# Altium schematic sheet A1-MAIN.SchDoc
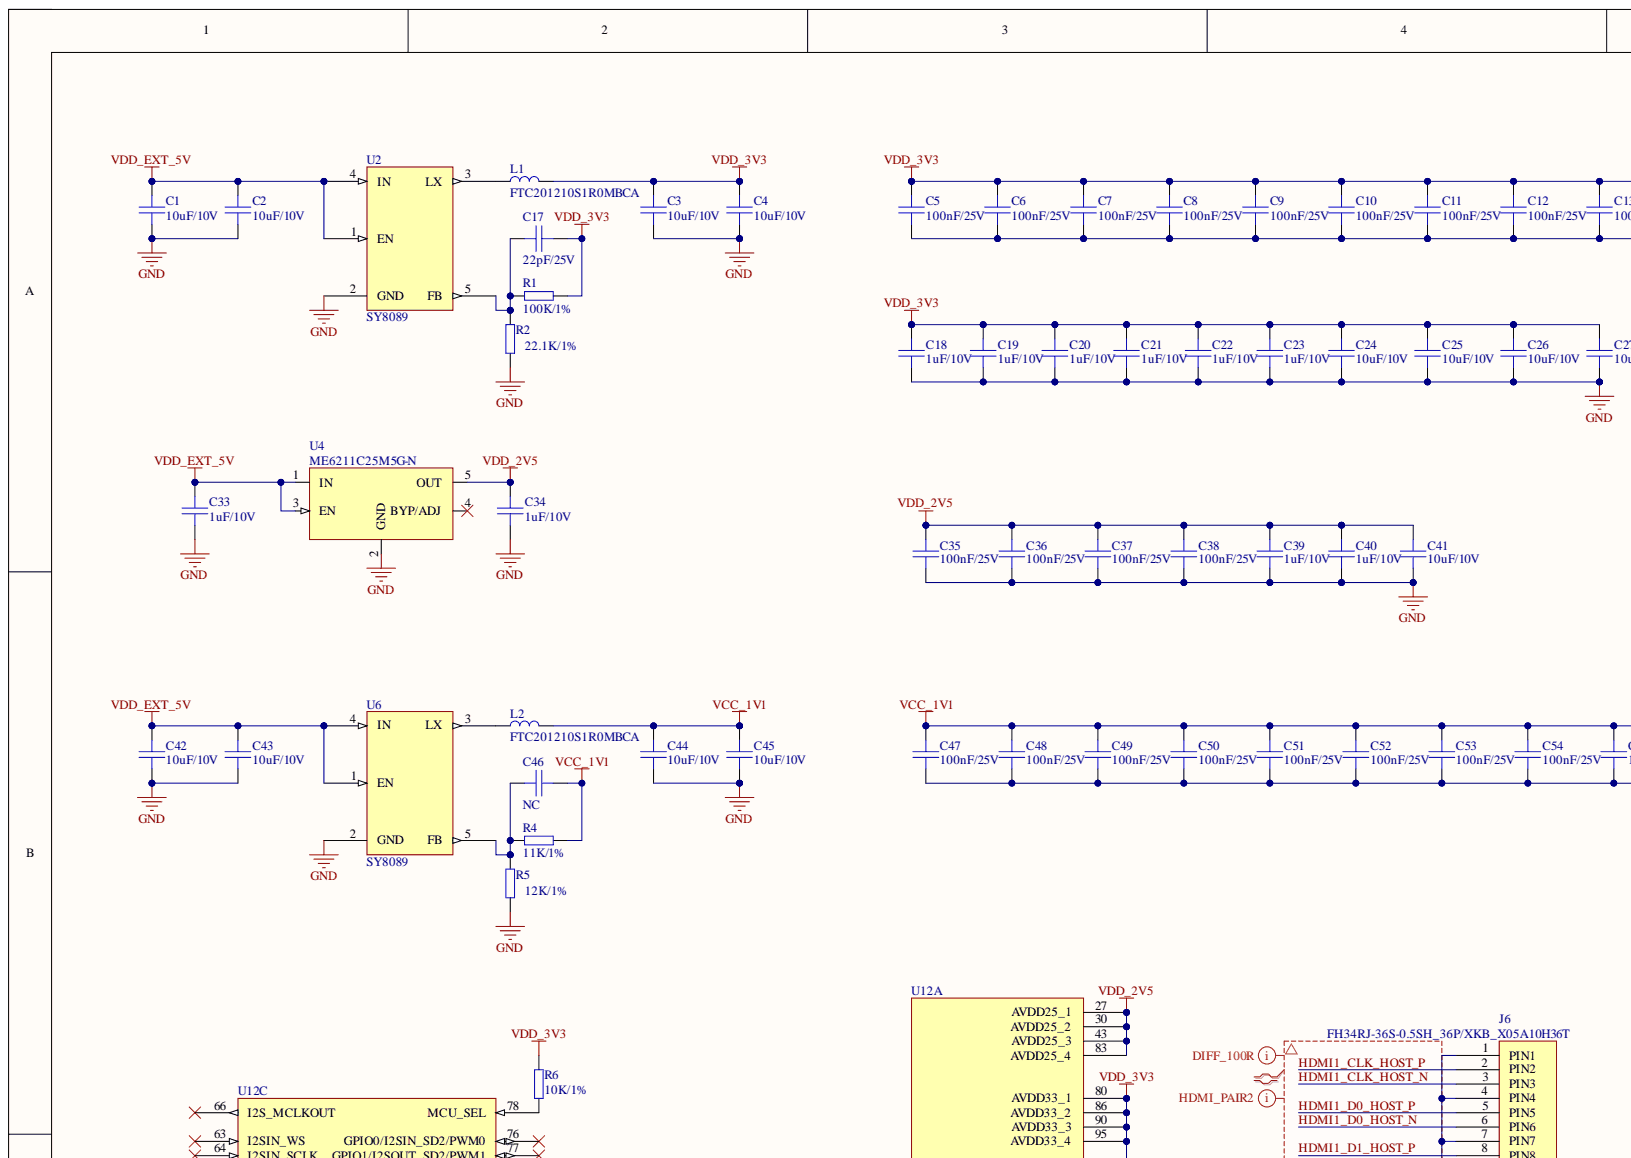
[diagram p0: A1-MAIN.SchDoc, top left]
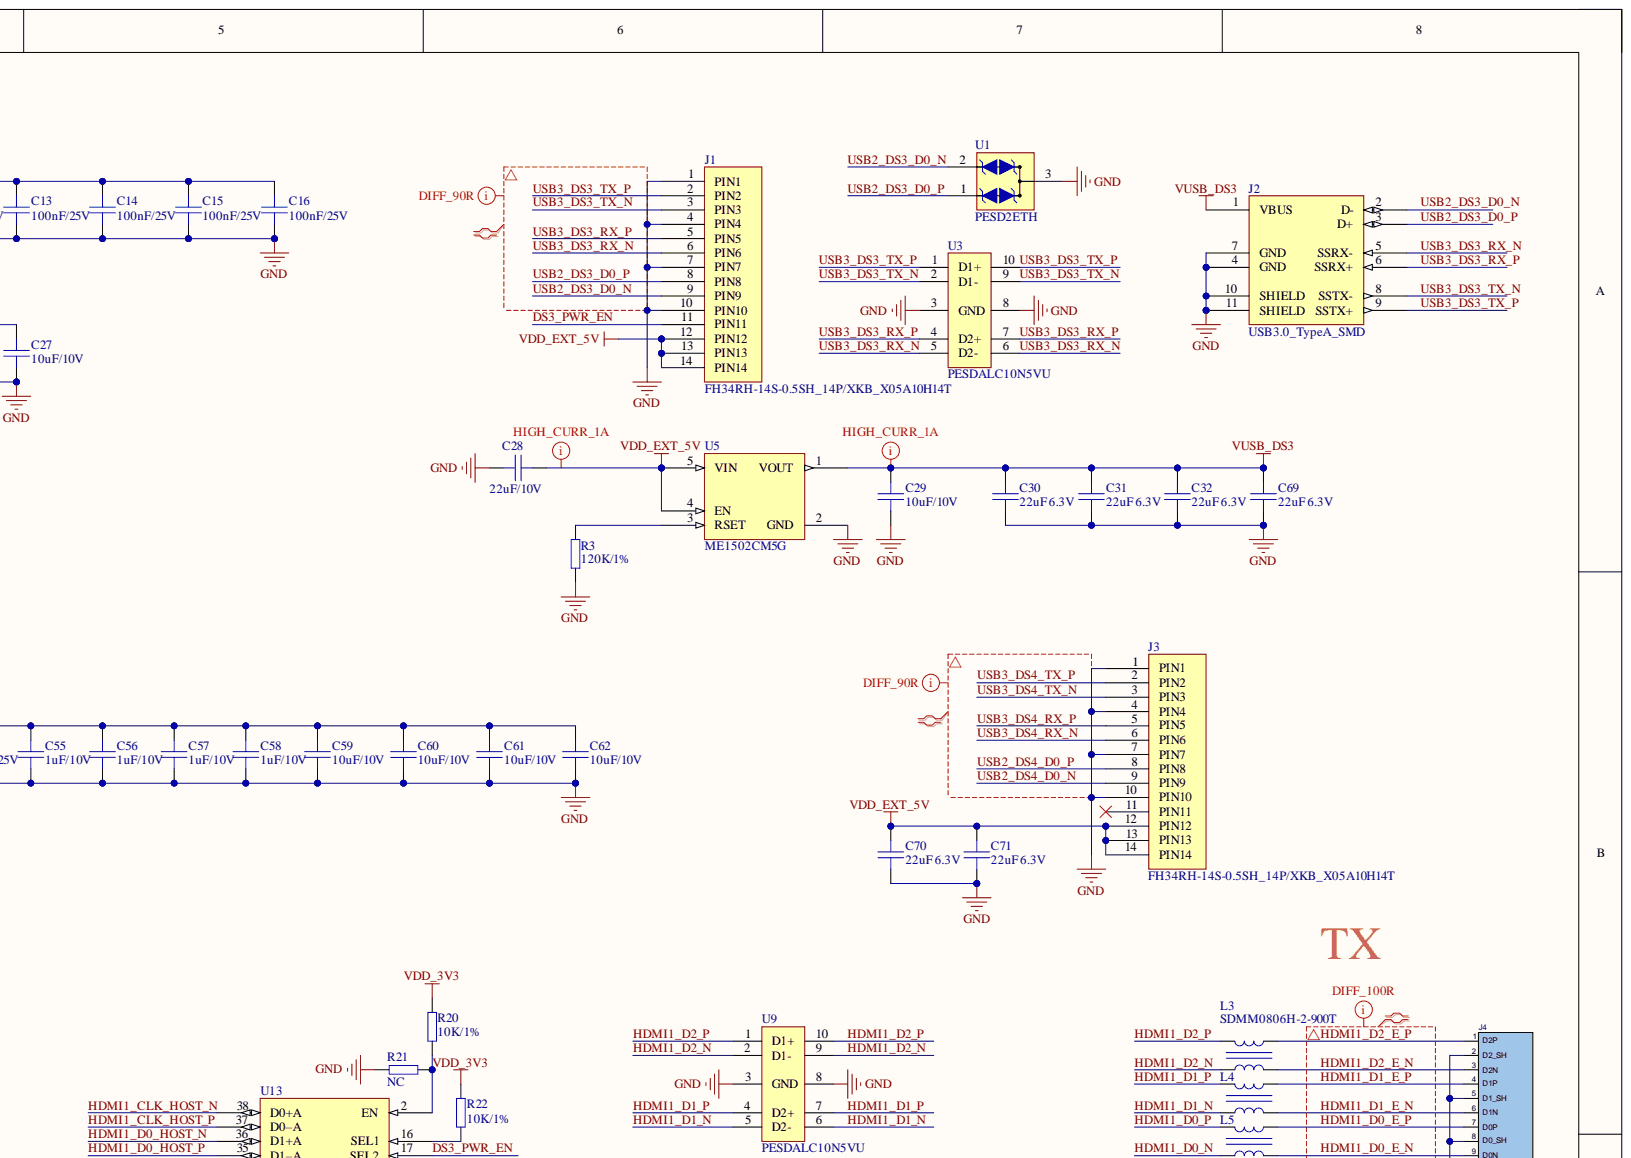
[diagram p1: A1-MAIN.SchDoc, top right]
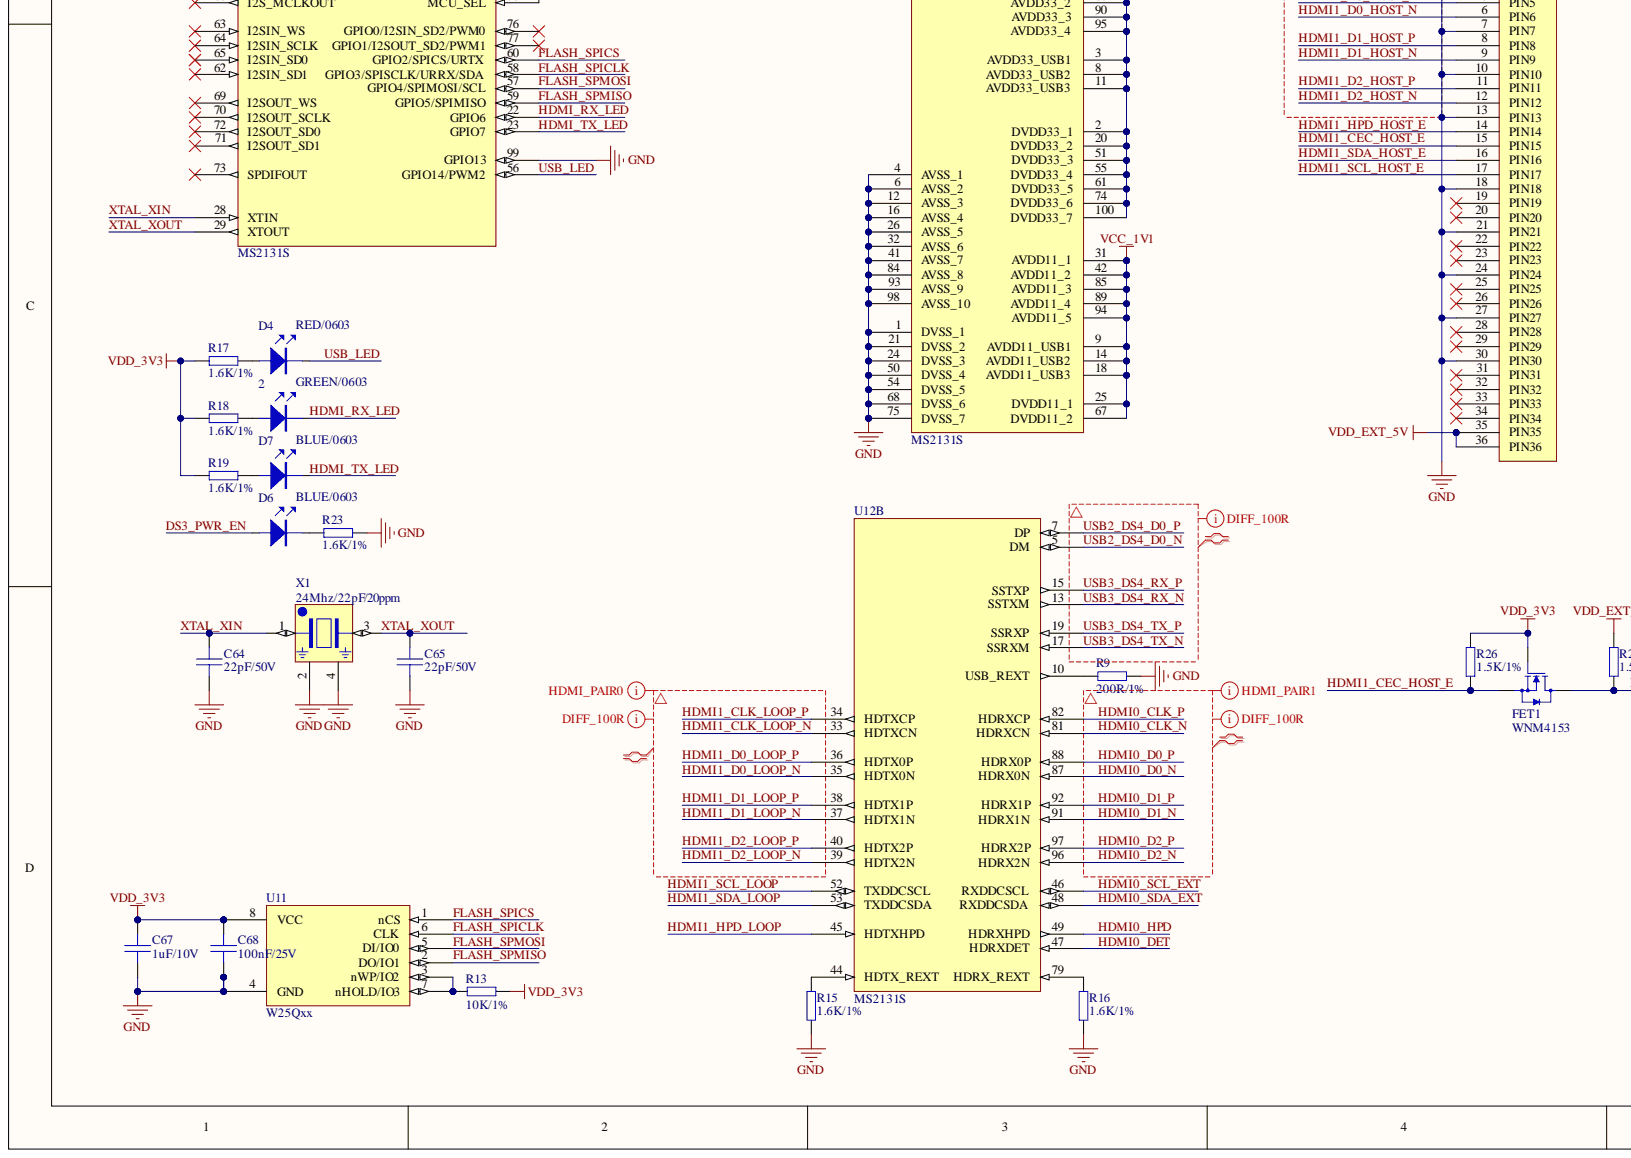
[diagram p2: A1-MAIN.SchDoc, bottom left]
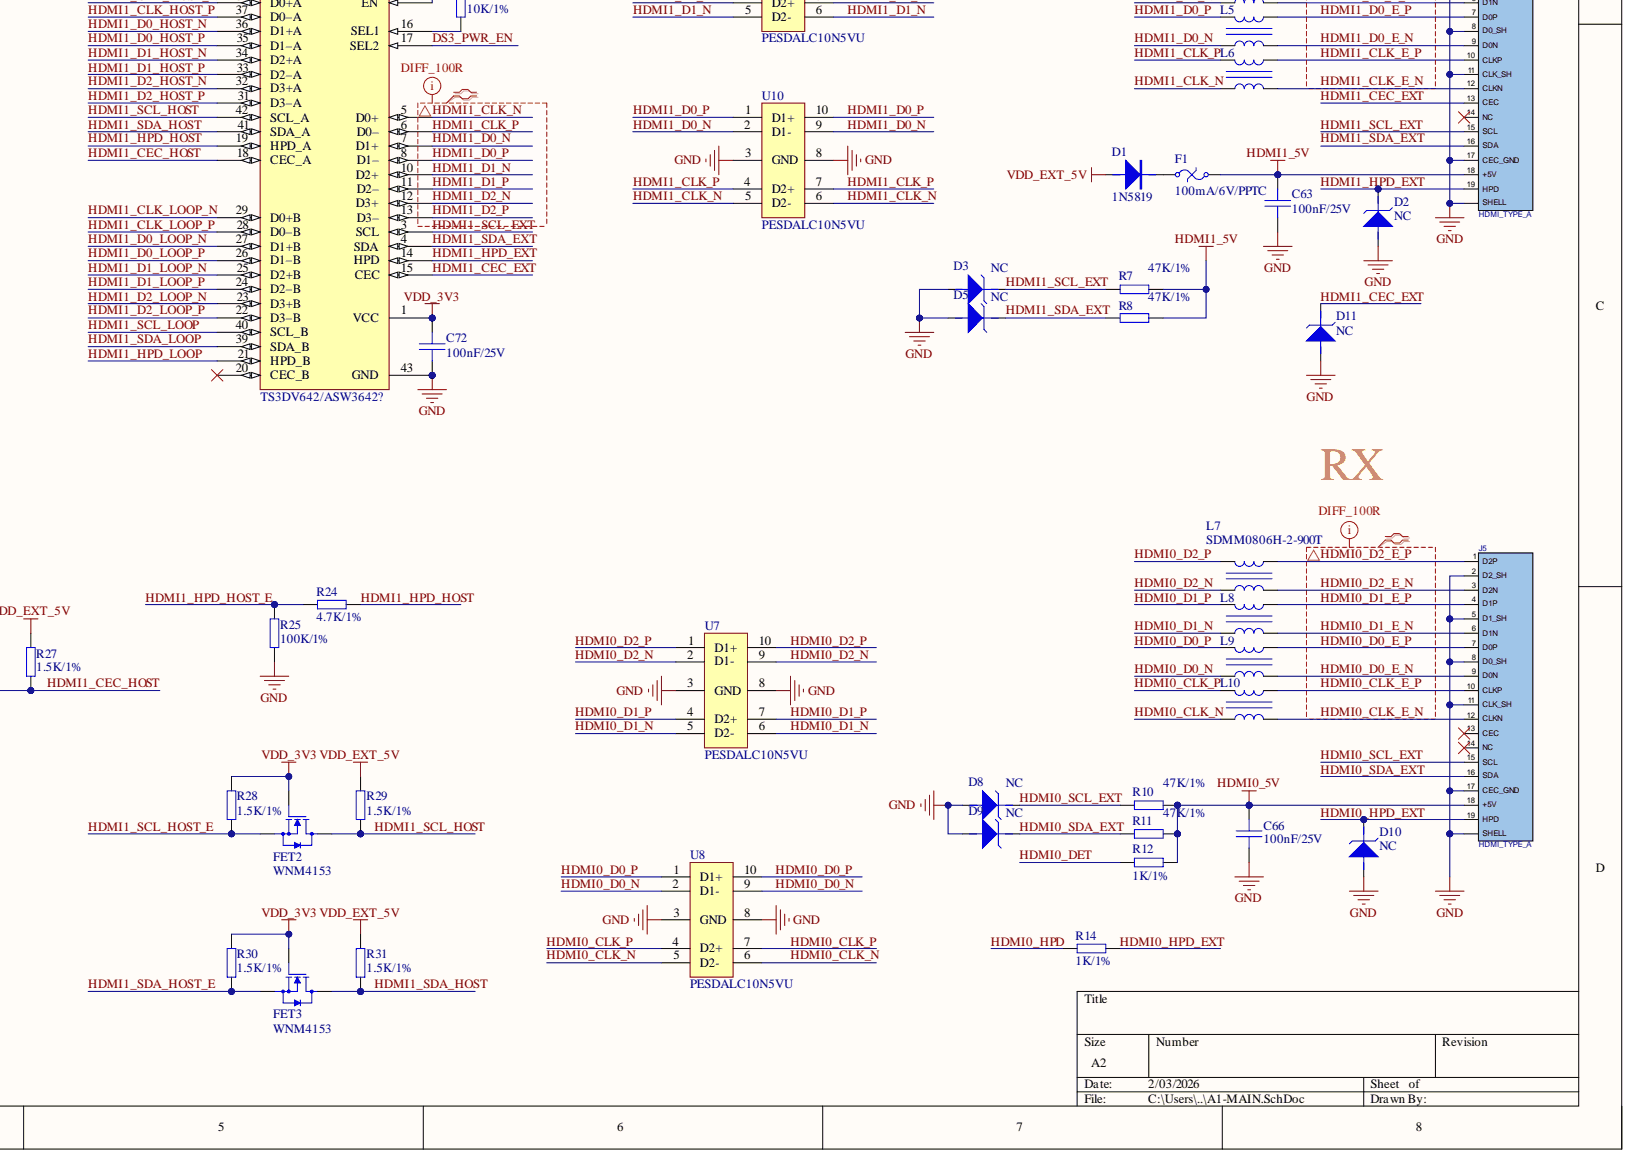
[diagram p3: A1-MAIN.SchDoc, bottom right]

## Parts
2  GREEN/0603  [SMT_LED_0603]
C1  10uF/10V  [C0402-L-HD]
C2  10uF/10V  [C0402-L-HD]
C3  10uF/10V  [C0402-L-HD]
C4  10uF/10V  [C0402-L-HD]
C5  100nF/25V  [C0402-HD]
C6  100nF/25V  [C0402-HD]
C7  100nF/25V  [C0402-HD]
C8  100nF/25V  [C0402-HD]
C9  100nF/25V  [C0402-HD]
C10  100nF/25V  [C0402-HD]
C11  100nF/25V  [C0402-HD]
C12  100nF/25V  [C0402-HD]
C13  100nF/25V  [C0402-HD]
C14  100nF/25V  [C0402-HD]
C15  100nF/25V  [C0402-HD]
C16  100nF/25V  [C0402-HD]
C17  22pF/25V  [C0402-HD]
C18  1uF/10V  [C0402-HD]
C19  1uF/10V  [C0402-HD]
C20  1uF/10V  [C0402-HD]
C21  1uF/10V  [C0402-HD]
C22  1uF/10V  [C0402-HD]
C23  1uF/10V  [C0402-HD]
C24  10uF/10V  [C0402-L-HD]
C25  10uF/10V  [C0402-L-HD]
C26  10uF/10V  [C0402-L-HD]
C27  10uF/10V  [C0402-L-HD]
C28  22uF/10V  [C0603-L-HD]
C29  10uF/10V  [C0402-L-HD]
C30  22uF 6.3V  [C0603-L-HD]
C31  22uF 6.3V  [C0603-L-HD]
C32  22uF 6.3V  [C0603-L-HD]
C33  1uF/10V  [C0402-HD]
C34  1uF/10V  [C0402-HD]
C35  100nF/25V  [C0402-HD]
C36  100nF/25V  [C0402-HD]
C37  100nF/25V  [C0402-HD]
C38  100nF/25V  [C0402-HD]
C39  1uF/10V  [C0402-HD]
C40  1uF/10V  [C0402-HD]
C41  10uF/10V  [C0402-L-HD]
C42  10uF/10V  [C0402-L-HD]
C43  10uF/10V  [C0402-L-HD]
C44  10uF/10V  [C0402-L-HD]
C45  10uF/10V  [C0402-L-HD]
C46  NC  [C0402-HD]
C47  100nF/25V  [C0402-HD]
C48  100nF/25V  [C0402-HD]
C49  100nF/25V  [C0402-HD]
C50  100nF/25V  [C0402-HD]
C51  100nF/25V  [C0402-HD]
C52  100nF/25V  [C0402-HD]
C53  100nF/25V  [C0402-HD]
C54  100nF/25V  [C0402-HD]
C55  1uF/10V  [C0402-HD]
C56  1uF/10V  [C0402-HD]
C57  1uF/10V  [C0402-HD]
C58  1uF/10V  [C0402-HD]
C59  10uF/10V  [C0402-L-HD]
C60  10uF/10V  [C0402-L-HD]
C61  10uF/10V  [C0402-L-HD]
C62  10uF/10V  [C0402-L-HD]
C63  100nF/25V  [C0402-HD]
C64  22pF/50V  [C0402-HD]
C65  22pF/50V  [C0402-HD]
C66  100nF/25V  [C0402-HD]
C67  1uF/10V  [C0402-HD]
C68  100nF/25V  [C0402-HD]
C69  22uF 6.3V  [C0603-L-HD]
C70  22uF 6.3V  [C0603-L-HD]
C71  22uF 6.3V  [C0603-L-HD]
C72  100nF/25V  [C0402-HD]
D1  1N5819  [SMT_DIODE_SOD_323]
D2  NC  [SOD-323FL-HD]
D3  NC  [SOD-323FL-HD]
D4  RED/0603  [SMT_LED_0603]
D5  NC  [SOD-323FL-HD]
D6  BLUE/0603  [SMT_LED_0603]
D7  BLUE/0603  [SMT_LED_0603]
D8  NC  [SOD-323FL-HD]
D9  NC  [SOD-323FL-HD]
D10  NC  [SOD-323FL-HD]
D11  NC  [SOD-323FL-HD]
F1  100mA/6V/PPTC  [SMT_FUSE_0805]
FET1  WNM4153  [SOT-233-HD]
FET2  WNM4153  [SOT-233-HD]
FET3  WNM4153  [SOT-233-HD]
J1  FH34RH-14S-0.5SH_14P/XKB_X05A10H14T  [FH34RH-14S-0.5SH_14P]
J2  USB3.0_TypeA_SMD  [USB-3.0-SMD_U-A-39DS-Y-1]
J3  FH34RH-14S-0.5SH_14P/XKB_X05A10H14T  [FH34RH-14S-0.5SH_14P]
J4  HDMI_TYPE_A  [CON_HDMI_A_SMD]
J5  HDMI_TYPE_A  [CON_HDMI_A_SMD]
J6  FH34RJ-36S-0.5SH_36P/XKB_X05A10H36T  [FH34RJ-36S-0.5SH_36P]
L1  FTC201210S1R0MBCA  [FTC201210S]
L2  FTC201210S1R0MBCA  [FTC201210S]
L3  SDMM0806H-2-900T  [SDMM0806]
L4  SDMM0806H-2-900T  [SDMM0806]
L5  SDMM0806H-2-900T  [SDMM0806]
L6  SDMM0806H-2-900T  [SDMM0806]
L7  SDMM0806H-2-900T  [SDMM0806]
L8  SDMM0806H-2-900T  [SDMM0806]
L9  SDMM0806H-2-900T  [SDMM0806]
L10  SDMM0806H-2-900T  [SDMM0806]
R1  100K/1%  [R0402-HD]
R2  22.1K/1%  [R0402-HD]
R3  120K/1%  [R0402-HD]
R4  11K/1%  [R0402-HD]
R5  12K/1%  [R0402-HD]
R6  10K/1%  [R0402-HD]
R7  47K/1%  [R0402-HD]
R8  47K/1%  [R0402-HD]
R9  200R/1%  [R0402-HD]
R10  47K/1%  [R0402-HD]
R11  47K/1%  [R0402-HD]
R12  1K/1%  [R0402-HD]
R13  10K/1%  [R0402-HD]
R14  1K/1%  [R0402-HD]
R15  1.6K/1%  [R0402-HD]
R16  1.6K/1%  [R0402-HD]
R17  1.6K/1%  [R0402-HD]
R18  1.6K/1%  [R0402-HD]
R19  1.6K/1%  [R0402-HD]
R20  10K/1%  [R0402-HD]
R21  NC  [R0402-HD]
R22  10K/1%  [R0402-HD]
R23  1.6K/1%  [R0402-HD]
R24  4.7K/1%  [R0402-HD]
R25  100K/1%  [R0402-HD]
R26  1.5K/1%  [R0402-HD]
R27  1.5K/1%  [R0402-HD]
R28  1.5K/1%  [R0402-HD]
R29  1.5K/1%  [R0402-HD]
R30  1.5K/1%  [R0402-HD]
R31  1.5K/1%  [R0402-HD]
U1  PESD2ETH  [SOT-233-HD]
U2  SY8089  [SOT23-5]
U3  PESDALC10N5VU  [R-PSON-N10]
U4  ME6211C25M5G-N  [SOT23-5]
U5  ME1502CM5G  [SOT-235-HD]
U6  SY8089  [SOT23-5]
U7  PESDALC10N5VU  [R-PSON-N10]
U8  PESDALC10N5VU  [R-PSON-N10]
U9  PESDALC10N5VU  [R-PSON-N10]
U10  PESDALC10N5VU  [R-PSON-N10]
U11  W25Qxx  [SOP-8]
U12  MS2131S  [TSQFP50P1600X1600X120-100L]  (parts A,B,C)
U13  TS3DV642/ASW3642?  [WQFN-42]
X1  24Mhz/22pF/20ppm  [SMT_XTAL_2520]

## Nets
GND: C1.2 C2.2 C3.2 C4.2 C5.2 C6.2 C7.2 C8.2 C9.2 C10.2 C11.2 C12.2 C13.2 C14.2 C15.2 C16.2 C18.2 C19.2 C20.2 C21.2 C22.2 C23.2 C24.2 C25.2 C26.2 C27.2 C28.1 C29.2 C30.1 C31.1 C32.1 C33.2 C34.2 C35.2 C36.2 C37.2 C38.2 C39.2 C40.2 C41.2 C42.2 C43.2 C44.2 C45.2 C47.2 C48.2 C49.2 C50.2 C51.2 C52.2 C53.2 C54.2 C55.2 C56.2 C57.2 C58.2 C59.2 C60.2 C61.2 C62.2 C63.2 C64.1 C65.1 C66.2 C67.2 C68.2 C69.1 C70.1 C71.1 C72.2 D2.1 D3.1 D5.1 D8.1 D9.1 D10.1 D11.1 J1.1(PIN1) J1.4(PIN4) J1.7(PIN7) J1.10(PIN10) J2.4(GND) J2.7(GND) J2.10(SHIELD) J2.11(SHIELD) J3.1(PIN1) J3.4(PIN4) J3.7(PIN7) J3.10(PIN10) J4.0(SHELL) J4.2(D2_SH) J4.5(D1_SH) J4.8(D0_SH) J4.11(CLK_SH) J4.17(CEC_GND) J5.0(SHELL) J5.2(D2_SH) J5.5(D1_SH) J5.8(D0_SH) J5.11(CLK_SH) J5.17(CEC_GND) J6.1(PIN1) J6.4(PIN4) J6.7(PIN7) J6.10(PIN10) J6.13(PIN13) J6.18(PIN18) J6.21(PIN21) J6.24(PIN24) J6.27(PIN27) J6.30(PIN30) R2.1 R3.2 R5.1 R9.1 R15.1 R16.1 R21.2 R23.1 R25.1 U1.3 U2.2(GND) U3.3 U3.8(GND) U4.2(GND) U5.2(GND) U6.2(GND) U7.3 U7.8(GND) U8.3 U8.8(GND) U9.3 U9.8(GND) U10.3 U10.8(GND) U11.4(GND) U12.1(DVSS_1) U12.4(AVSS_1) U12.6(AVSS_2) U12.12(AVSS_3) U12.16(AVSS_4) U12.21(DVSS_2) U12.24(DVSS_3) U12.26(AVSS_5) U12.32(AVSS_6) U12.41(AVSS_7) U12.50(DVSS_4) U12.54(DVSS_5) U12.68(DVSS_6) U12.75(DVSS_7) U12.84(AVSS_8) U12.93(AVSS_9) U12.98(AVSS_10) U12.99(GPIO13) U13.43(GND) X1.2 X1.4
HDMI0_5V: C66.1 J5.18(+5V) R10.1 R11.1 R12.2
HDMI1_5V: C63.1 F1.2 J4.18(+5V) R7.1 R8.1
VCC_1V1: C44.1 C45.1 C46.2 C47.1 C48.1 C49.1 C50.1 C51.1 C52.1 C53.1 C54.1 C55.1 C56.1 C57.1 C58.1 C59.1 C60.1 C61.1 C62.1 L2.1 R4.2 U12.9(AVDD11_USB1) U12.14(AVDD11_USB2) U12.18(AVDD11_USB3) U12.25(DVDD11_1) U12.31(AVDD11_1) U12.42(AVDD11_2) U12.67(DVDD11_2) U12.85(AVDD11_3) U12.89(AVDD11_4) U12.94(AVDD11_5)
VDD_2V5: C34.1 C35.1 C36.1 C37.1 C38.1 C39.1 C40.1 C41.1 U4.5(OUT) U12.27(AVDD25_1) U12.30(AVDD25_2) U12.43(AVDD25_3) U12.83(AVDD25_4)
VDD_3V3: C3.1 C4.1 C5.1 C6.1 C7.1 C8.1 C9.1 C10.1 C11.1 C12.1 C13.1 C14.1 C15.1 C16.1 C17.2 C18.1 C19.1 C20.1 C21.1 C22.1 C23.1 C24.1 C25.1 C26.1 C27.1 C67.1 C68.1 C72.1 FET1.1 FET2.1 FET3.1 L1.1 R1.2 R6.2 R13.1 R17.2 R18.2 R19.2 R20.2 R22.2 R26.1 R28.1 R30.1 U11.8(VCC) U12.2(DVDD33_1) U12.3(AVDD33_USB1) U12.8(AVDD33_USB2) U12.11(AVDD33_USB3) U12.20(DVDD33_2) U12.51(DVDD33_3) U12.55(DVDD33_4) U12.61(DVDD33_5) U12.74(DVDD33_6) U12.80(AVDD33_1) U12.86(AVDD33_2) U12.90(AVDD33_3) U12.95(AVDD33_4) U12.100(DVDD33_7) U13.1(VCC)
VDD_EXT_5V: C1.1 C2.1 C28.2 C33.1 C42.1 C43.1 C70.2 C71.2 D1.1 J1.12(PIN12) J1.13(PIN13) J1.14(PIN14) J3.12(PIN12) J3.13(PIN13) J3.14(PIN14) J6.35(PIN35) J6.36(PIN36) R27.1 R29.1 R31.1 U2.1(EN) U2.4(IN) U4.1(IN) U4.3(EN) U5.4(EN) U5.5(VIN) U6.1(EN) U6.4(IN)
VUSB_DS3: C29.1 C30.2 C31.2 C32.2 C69.2 J2.1(VBUS) U5.1(VOUT)
DS3_PWR_EN: D6.1 J1.11(PIN11) U13.17(SEL2)
FLASH_SPICLK: U11.6(CLK) U12.58(GPIO3/SPISCLK/URRX/SDA)
FLASH_SPICS: U11.1(nCS) U12.60(GPIO2/SPICS/URTX)
FLASH_SPMISO: U11.2(DO/IO1) U12.59(GPIO5/SPIMISO)
FLASH_SPMOSI: U11.5(DI/IO0) U12.57(GPIO4/SPIMOSI/SCL)
HDMI0_CLK_E_N: J5.12(CLKN) L10.3
HDMI0_CLK_E_P: J5.10(CLKP) L10.4
HDMI0_CLK_N: L10.2 U8.5(D2-) U8.6 U12.81(HDRXCN)
HDMI0_CLK_P: L10.1 U8.4 U8.7(D2+) U12.82(HDRXCP)
HDMI0_D0_E_N: J5.9(D0N) L9.3
HDMI0_D0_E_P: J5.7(D0P) L9.4
HDMI0_D0_N: L9.2 U8.2(D1-) U8.9 U12.87(HDRX0N)
HDMI0_D0_P: L9.1 U8.1 U8.10(D1+) U12.88(HDRX0P)
HDMI0_D1_E_N: J5.6(D1N) L8.3
HDMI0_D1_E_P: J5.4(D1P) L8.4
HDMI0_D1_N: L8.2 U7.5 U7.6(D2-) U12.91(HDRX1N)
HDMI0_D1_P: L8.1 U7.4 U7.7(D2+) U12.92(HDRX1P)
HDMI0_D2_E_N: J5.3(D2N) L7.3
HDMI0_D2_E_P: J5.1(D2P) L7.4
HDMI0_D2_N: L7.2 U7.2 U7.9(D1-) U12.96(HDRX2N)
HDMI0_D2_P: L7.1 U7.1 U7.10(D1+) U12.97(HDRX2P)
HDMI0_DET: R12.1 U12.47(HDRXDET)
HDMI0_HPD: R14.1 U12.49(HDRXHPD)
HDMI0_HPD_EXT: D10.2 J5.19(HPD) R14.2
HDMI0_SCL_EXT: D8.2 J5.15(SCL) R10.2 U12.46(RXDDCSCL)
HDMI0_SDA_EXT: D9.2 J5.16(SDA) R11.2 U12.48(RXDDCSDA)
HDMI1_CEC_EXT: D11.2 J4.13(CEC) U13.15(CEC)
HDMI1_CEC_HOST: FET1.3 R27.2 U13.18(CEC_A)
HDMI1_CEC_HOST_E: FET1.2 J6.15(PIN15) R26.2
HDMI1_CLK_E_N: J4.12(CLKN) L6.3
HDMI1_CLK_E_P: J4.10(CLKP) L6.4
HDMI1_CLK_HOST_N: J6.3(PIN3) U13.38(D0+A)
HDMI1_CLK_HOST_P: J6.2(PIN2) U13.37(D0–A)
HDMI1_CLK_LOOP_N: U12.33(HDTXCN) U13.29(D0+B)
HDMI1_CLK_LOOP_P: U12.34(HDTXCP) U13.28(D0–B)
HDMI1_CLK_N: L6.2 U10.5 U10.6(D2-) U13.5(D0+)
HDMI1_CLK_P: L6.1 U10.4 U10.7(D2+) U13.6(D0–)
HDMI1_D0_E_N: J4.9(D0N) L5.3
HDMI1_D0_E_P: J4.7(D0P) L5.4
HDMI1_D0_HOST_N: J6.6(PIN6) U13.36(D1+A)
HDMI1_D0_HOST_P: J6.5(PIN5) U13.35(D1–A)
HDMI1_D0_LOOP_N: U12.35(HDTX0N) U13.27(D1+B)
HDMI1_D0_LOOP_P: U12.36(HDTX0P) U13.26(D1–B)
HDMI1_D0_N: L5.2 U10.2 U10.9(D1-) U13.7(D1+)
HDMI1_D0_P: L5.1 U10.1 U10.10(D1+) U13.8(D1–)
HDMI1_D1_E_N: J4.6(D1N) L4.3
HDMI1_D1_E_P: J4.4(D1P) L4.4
HDMI1_D1_HOST_N: J6.9(PIN9) U13.34(D2+A)
HDMI1_D1_HOST_P: J6.8(PIN8) U13.33(D2–A)
HDMI1_D1_LOOP_N: U12.37(HDTX1N) U13.25(D2+B)
HDMI1_D1_LOOP_P: U12.38(HDTX1P) U13.24(D2–B)
HDMI1_D1_N: L4.2 U9.5 U9.6(D2-) U13.10(D2+)
HDMI1_D1_P: L4.1 U9.4 U9.7(D2+) U13.11(D2–)
HDMI1_D2_E_N: J4.3(D2N) L3.3
HDMI1_D2_E_P: J4.1(D2P) L3.4
HDMI1_D2_HOST_N: J6.12(PIN12) U13.32(D3+A)
HDMI1_D2_HOST_P: J6.11(PIN11) U13.31(D3–A)
HDMI1_D2_LOOP_N: U12.39(HDTX2N) U13.23(D3+B)
HDMI1_D2_LOOP_P: U12.40(HDTX2P) U13.22(D3–B)
HDMI1_D2_N: L3.2 U9.2 U9.9(D1-) U13.12(D3+)
HDMI1_D2_P: L3.1 U9.1 U9.10(D1+) U13.13(D3–)
HDMI1_HPD_EXT: D2.2 J4.19(HPD) U13.14(HPD)
HDMI1_HPD_HOST: R24.1 U13.19(HPD_A)
HDMI1_HPD_HOST_E: J6.14(PIN14) R24.2 R25.2
HDMI1_HPD_LOOP: U12.45(HDTXHPD) U13.21(HPD_B)
HDMI1_SCL_EXT: D3.2 J4.15(SCL) R7.2 U13.3(SCL)
HDMI1_SCL_HOST: FET2.3 R29.2 U13.42(SCL_A)
HDMI1_SCL_HOST_E: FET2.2 J6.17(PIN17) R28.2
HDMI1_SCL_LOOP: U12.52(TXDDCSCL) U13.40(SCL_B)
HDMI1_SDA_EXT: D5.2 J4.16(SDA) R8.2 U13.4(SDA)
HDMI1_SDA_HOST: FET3.3 R31.2 U13.41(SDA_A)
HDMI1_SDA_HOST_E: FET3.2 J6.16(PIN16) R30.2
HDMI1_SDA_LOOP: U12.53(TXDDCSDA) U13.39(SDA_B)
HDMI_RX_LED: 2.2 U12.22(GPIO6)
HDMI_TX_LED: D7.2 U12.23(GPIO7)
USB2_DS3_D0_N: J1.9(PIN9) J2.2(D-) U1.2
USB2_DS3_D0_P: J1.8(PIN8) J2.3(D+) U1.1
USB2_DS4_D0_N: J3.9(PIN9) U12.5(DM)
USB2_DS4_D0_P: J3.8(PIN8) U12.7(DP)
USB3_DS3_RX_N: J1.6(PIN6) J2.5(SSRX-) U3.5(D2-) U3.6
USB3_DS3_RX_P: J1.5(PIN5) J2.6(SSRX+) U3.4 U3.7(D2+)
USB3_DS3_TX_N: J1.3(PIN3) J2.8(SSTX-) U3.2(D1-) U3.9
USB3_DS3_TX_P: J1.2(PIN2) J2.9(SSTX+) U3.1 U3.10(D1+)
USB3_DS4_RX_N: J3.6(PIN6) U12.13(SSTXM)
USB3_DS4_RX_P: J3.5(PIN5) U12.15(SSTXP)
USB3_DS4_TX_N: J3.3(PIN3) U12.17(SSRXM)
USB3_DS4_TX_P: J3.2(PIN2) U12.19(SSRXP)
USB_LED: D4.2 U12.56(GPIO14/PWM2)
XTAL_XIN: C64.2 U12.28(XTIN) X1.1
XTAL_XOUT: C65.2 U12.29(XTOUT) X1.3
Net2_1: 2.1 R18.1
NetC17_1: C17.1 R1.1 R2.2 U2.5(FB)
NetC46_1: C46.1 R4.1 R5.2 U6.5(FB)
NetD1_2: D1.2 F1.1
NetD4_1: D4.1 R17.1
NetD6_2: D6.2 R23.2
NetD7_1: D7.1 R19.1
NetL1_2: L1.2 U2.3(LX)
NetL2_2: L2.2 U6.3(LX)
NetR3_1: R3.1 U5.3(RSET)
NetR6_1: R6.1 U12.78(MCU_SEL)
NetR9_2: R9.2 U12.10(USB_REXT)
NetR13_2: R13.2 U11.3(nWP/IO2) U11.7(nHOLD/IO3)
NetR15_2: R15.2 U12.44(HDTX_REXT)
NetR16_2: R16.2 U12.79(HDRX_REXT)
NetR20_1: R20.1 R21.1 U13.2(EN)
NetR22_1: R22.1 U13.16(SEL1)

Not connected: J3 11(PIN11); J4 14(NC); J5 13(CEC) 14(NC); J6 19(PIN19) 20(PIN20) 22(PIN22) 23(PIN23) 25(PIN25) 26(PIN26) 28(PIN28) 29(PIN29) 31(PIN31) 32(PIN32) 33(PIN33) 34(PIN34); U4 4(BYP/ADJ); U12 62(I2SIN_SD1) 63(I2SIN_WS) 64(I2SIN_SCLK) 65(I2SIN_SD0) 66(I2S_MCLKOUT) 69(I2SOUT_WS) 70(I2SOUT_SCLK) 71(I2SOUT_SD1) 72(I2SOUT_SD0) 73(SPDIFOUT) 76(GPIO0/I2SIN_SD2/PWM0) 77(GPIO1/I2SOUT_SD2/PWM1); U13 20(CEC_B)

## Library data of the parts
2 D4 D6 D7 = SMT_LED_0603: Surface mount LED 0603; Component Kind: Standard; Library Name: Vowstar_Basic_Device.SchLib
C1-C28 C30-C72 = SMT_C_0603: Surface mount capacitor 0603; Component Kind: Standard; Library Name: Vowstar_Basic_Device.SchLib
C29 = SMT_C_0402: Surface mount capacitor 0402; Component Kind: Standard; Library Name: Vowstar_Basic_Device.SchLib
D1 = SMT_DIODE_SOD_323: Surface mount diode SOD-323(0805) Package; Component Kind: Standard; Library Name: Vowstar_Basic_Device.SchLib
D2 D3 D5 D8-D11 = SMT_DIODE_TVS_U_SOD_523: Surface mount unidirectional TVS diode SOD-523(0603) Package; Component Kind: Standard; Library Name: Vowstar_Basic_Device.SchLib
F1 = SMT_FUSE_0805: Component Kind: Standard; Description: SMT_FUSE_0805; Library Name: Vowstar_Basic_Device.SchLib
FET1-FET3 = SI2302-N-Ch-MOSFET: SI2302-N-Ch,3A, 20V.RDS(ON) = 0.082Ω @ VGS = 2.5 V; Component Kind: Standard; Library Name: Vowstar_Basic_Device.SchLib
J1 J3 = THT_Male_P_1x14: THT Male pin header strip 1x14; Component Kind: Standard; Library Name: Vowstar_Connector.SchLib
J4 J5 = CON_HDMI_TYPE_A: Component Kind: Standard; Library Name: LibMySelf_SCHLIB.SchLib
J6 = FPC_CONN_36P: Component Kind: Standard; Library Name: CONNECTOR.SchLib
L1 L2 = SMT_L_3.0mm: SMT Power Inductor, 3.3x3.3x1mm; Component Kind: Standard; Library Name: Vowstar_Basic_Device.SchLib
L3-L10 = ACM2012: Component Kind: Standard; Library Name: GENERAL-IC.SchLib
R1 R2 R4-R23 = SMT_R_0603: Surface mount resistor 0603; Component Kind: Standard; Library Name: Vowstar_Basic_Device.SchLib
R3 R24-R31 = SMT_R_0402: Surface mount resistor 0402; Component Kind: Standard; Library Name: Vowstar_Basic_Device.SchLib
U1 = ESD2CAN: Component Kind: Standard; Library Name: GENERAL-IC.SchLib
U2 U6 = SY8089: High Efficiency 5.5V, 2A continuous, 3A peak, 1MHz  Synchronous Step Down Regulator; Component Kind: Standard; Library Name: DCDC-CONVERTER.SchLib
U3 U7-U10 = TPD4E05U06: Component Kind: Standard; Library Name: GENERAL-IC.SchLib
U4 = SPX3819: 500mA, Low-Noise LDO Voltage Regulator; Component Kind: Standard; Library Name: Vowstar_IC_General.SchLib
U5 = ME1502: Component Kind: Standard; Library Name: GENERAL-IC.SchLib
U11 = W25QXX: Serial flash memory with dual and quad SPI; Component Kind: Standard; Library Name: Vowstar_IC_General.SchLib
U13 = TS3DV642: Component Kind: Standard; Library Name: HDMI_USB_Capture_IC.SchLib
X1 = SMT_XTAL: Passive crystal oscillator; Component Kind: Standard; Library Name: Vowstar_Basic_Device.SchLib
J2 = USB3.0_TypeA_SMD: Component Kind: Standard; Library Name: CONNECTOR.SchLib
U12 = MS2131S: Component Kind: Standard; Library Name: HDMI_USB_Capture_IC.SchLib
